# Altium schematic sheet CAN.SchDoc(CAN)
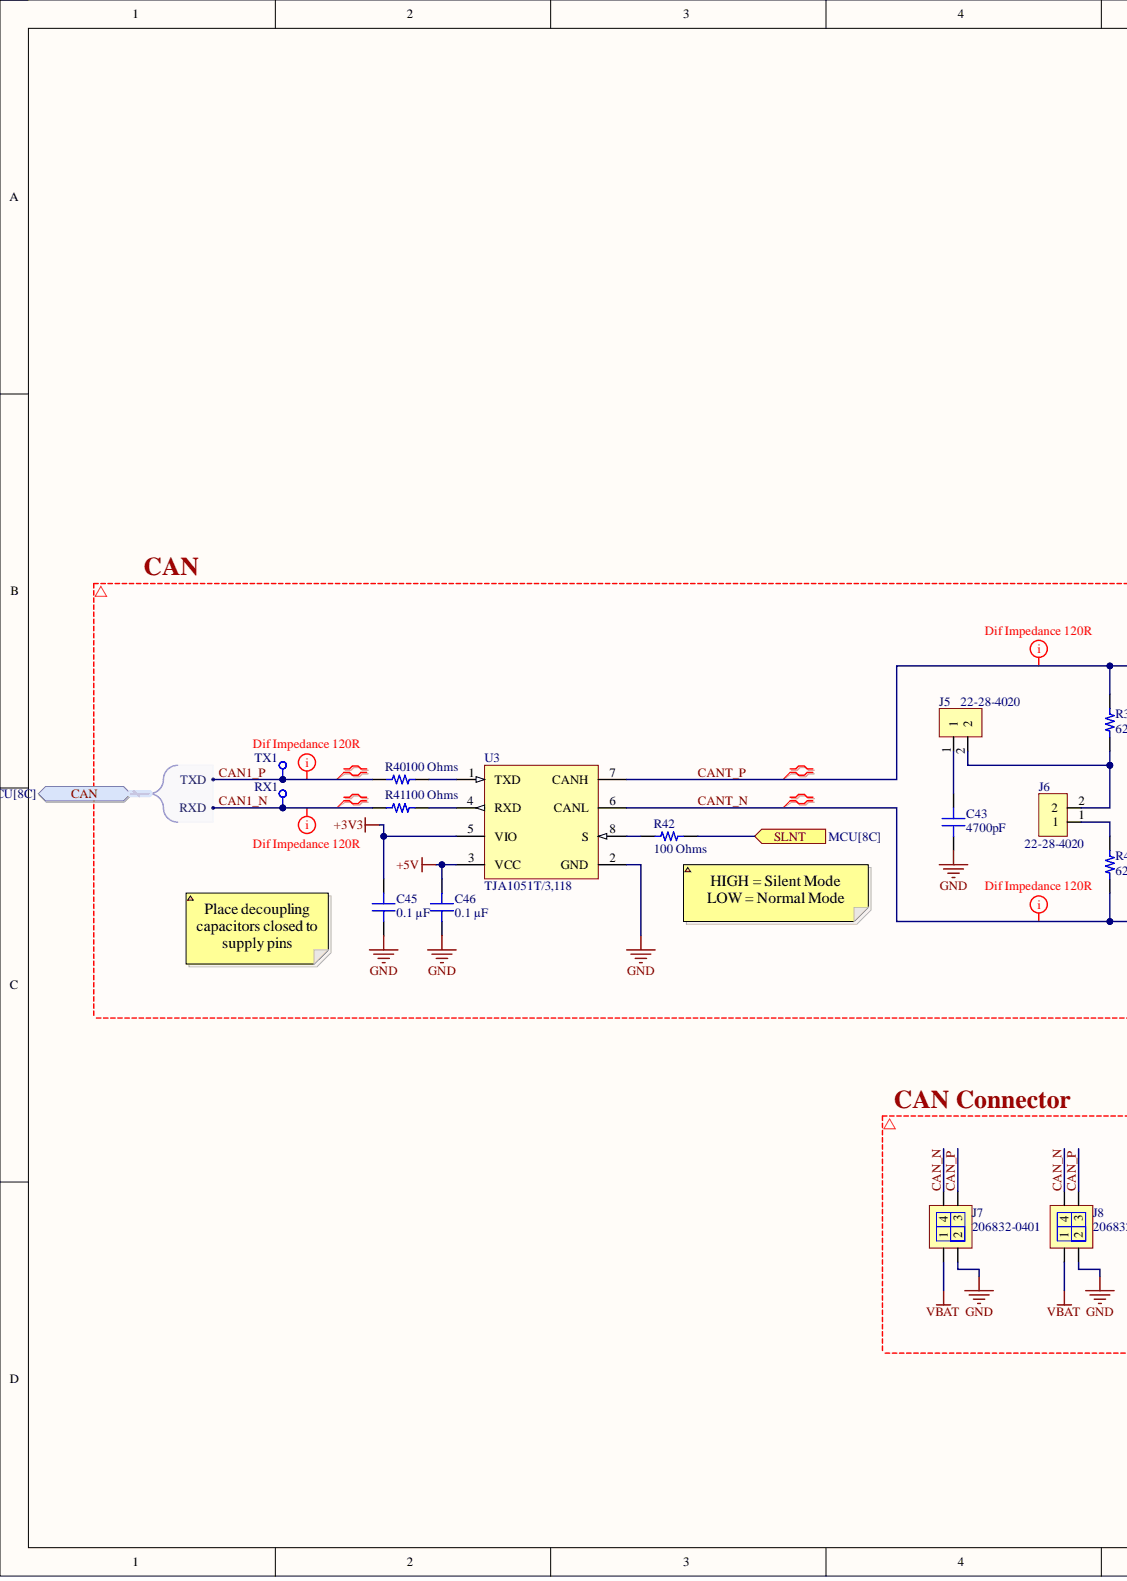
[diagram p0: CAN.SchDoc, left]
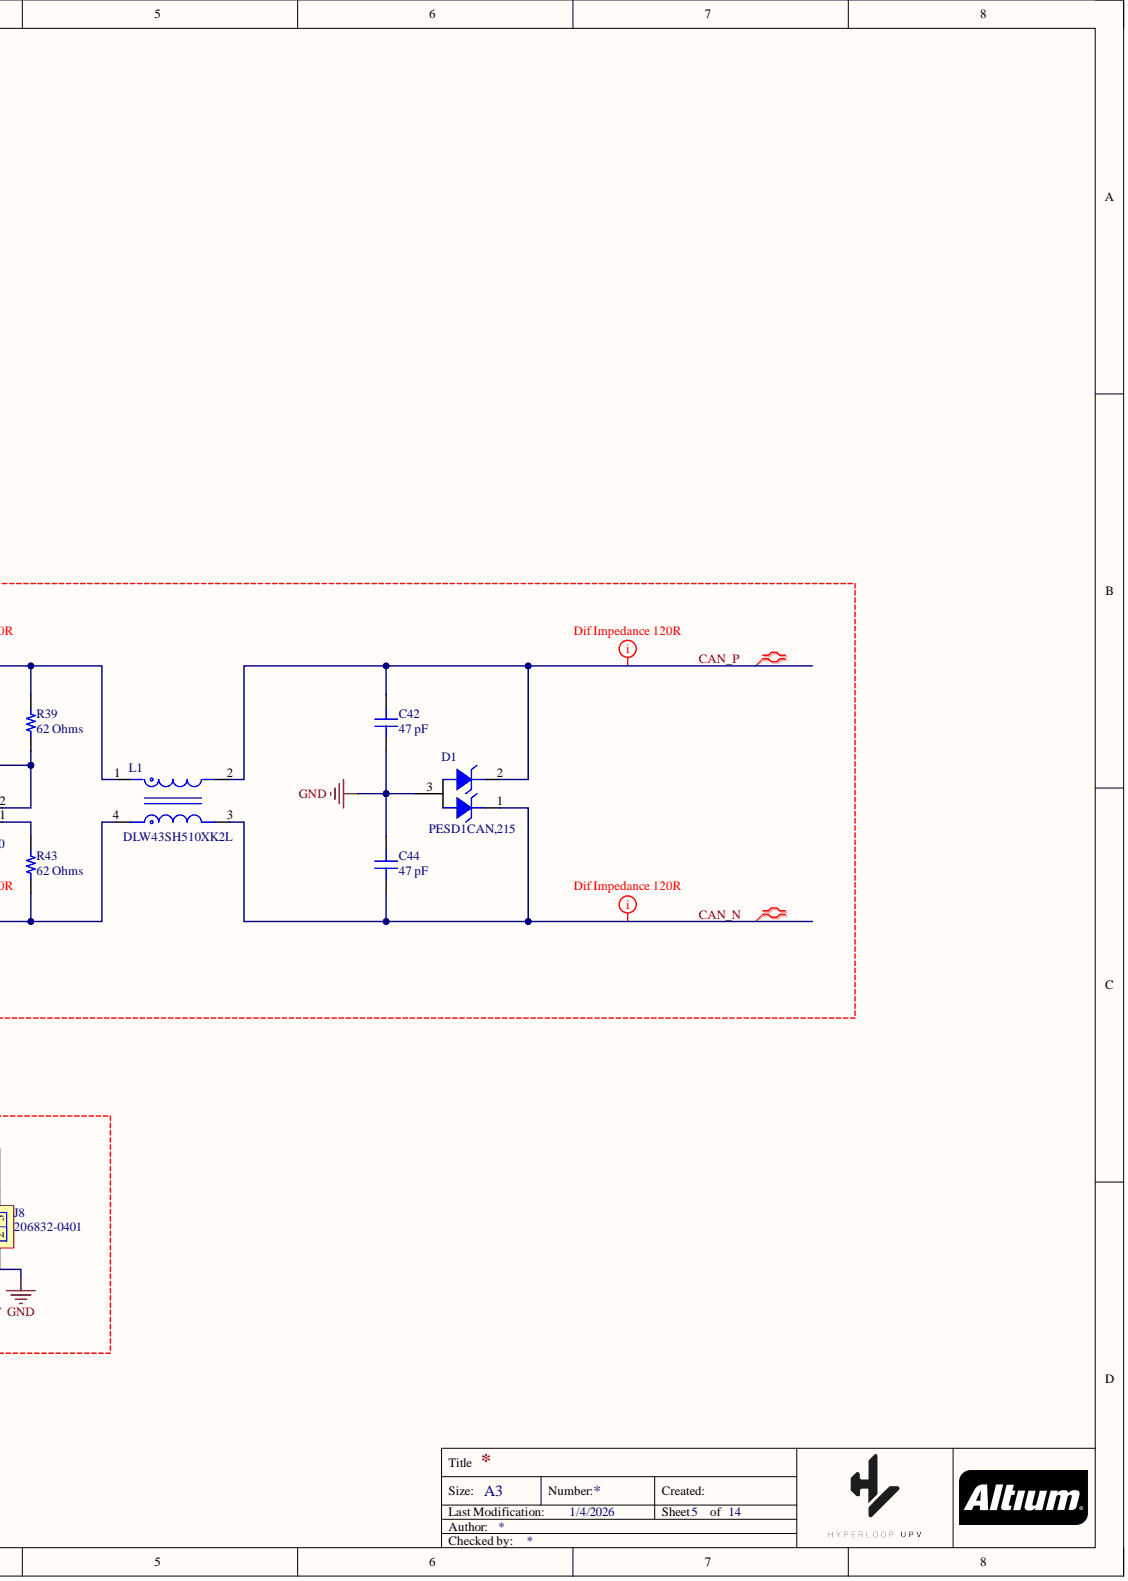
[diagram p1: CAN.SchDoc, right]

## Parts
C42  47 pF
C43  4700pF
C44  47 pF
C45  0.1 µF
C46  0.1 µF
D1  PESD1CAN,215
J5  22-28-4020
J6  22-28-4020
J7  206832-0401
J8  206832-0401
L1  DLW43SH510XK2L
R39  62 Ohms
R40  100 Ohms
R41  100 Ohms
R42  100 Ohms
R43  62 Ohms
RX1  Test point 1.2mm  (value not drawn)
TX1  Test point 1.2mm  (value not drawn)
U3  TJA1051T/3,118

## Ports
CAN, CAN.RXD, CAN.TXD, SLNT

## Nets
+3V3: C45.2 U3.5(VIO)
+5V: C46.2 U3.3(VCC)
GND: C42.1 C43.1 C44.2 C45.1 C46.1 D1.3 J7.2 J8.2 U3.2(GND)
VBAT: J7.1 J8.1
CAN1_N: R41.1 RX1.1 ; port CAN
CAN1_P: R40.1 TX1.1 ; port CAN
CAN_N: C44.1 D1.1 J7.4 J8.4 L1.3
CAN_P: C42.2 D1.2 J7.3 J8.3 L1.2
CANT_N: L1.4 R43.2 U3.6(CANL)
CANT_P: L1.1 R39.1 U3.7(CANH)
SLNT: R42.2 ; port SLNT
NetC43_2: C43.2 J5.1
NetJ5_2: J5.2 J6.2 R39.2
NetJ6_1: J6.1 R43.1
NetR40_2: R40.2 U3.1(TXD)
NetR41_2: R41.2 U3.4(RXD)
NetR42_1: R42.1 U3.8(S)

## Library data of the parts
C42 C44 = 885012006055: CAP CER 47PF 50V C0G/NP0 0603; manufacturer: Würth Elektronik; Database Table Name: Capacitors - Ceramic - 0603; Temperature Coefficient: C0G, NP0; Tolerance: ±5%; Applications: General Purpose; Capacitance: 47 pF; Component Kind: Standard; componentlink1description: Datasheet; componentlink1url: https://katalog.we-online.de/pbs/datasheet/885012006055.pdf; componentlink2description: DigiKey Link; componentlink2url: https://www.digikey.com/en/products/detail/würth-elektronik/885012006055/5453652; Device Package: 0603 (1608 Metric); lastupdated: 19/09/2022 13:01:16; Library Name: Celestial Altium Library - altium_library.DbLib; Lifecycle Status: Active; Minimum Order: 1; Mounting Type: Surface Mount, MLCC; Operating Temperature: -55°C ~ 125°C; Package / Case: 0603 (1608 Metric); packaging: TapeAndReel; Part Number: 885012006055; Part Status: Active; partid: 3510; price: 0.02; series: WCAP-CSGP; Size / Dimension: 0.063" L x 0.031" W (1.60mm x 0.80mm); Supplier 1: DigiKey; Supplier Part Number 1: 732-7797-1-ND; Thickness (Max): 0.034" (0.87mm); Value: 47 pF; Voltage - Rated: 50V
C43 = 06035C472JAT2A: CAP CER 4700PF 50V X7R 0603; manufacturer: AVX Corporation; Database Table Name: Capacitors - Ceramic - 0603; Tolerance: ±5%; Applications: General Purpose; Capacitance: 4700pF; Component Kind: Standard; componentlink1description: Datasheet; componentlink1url: http://datasheets.avx.com/X7RDielectric.pdf; componentlink2description: DigiKey Link; componentlink2url: http://digikey.com/product-detail/en/avx-corporation/06035C472JAT2A/478-10355-1-ND/6797516; Device Package: 0603 (1608 Metric); lastupdated: 02/09/2020 1:03:35; Library Name: Celestial Altium Library - altium_library.DbLib; Lifecycle Status: Active; Minimum Order: 1; Mounting Type: Surface Mount, MLCC; Operating Temperature: -55°C ~ 125°C; Package / Case: 0603 (1608 Metric); packaging: TapeAndReel; Part Number: 06035C472JAT2A; Part Status: Active; partid: 2778; price: 0.02; series: -; Size / Dimension: 0.063" L x 0.032" W (1.60mm x 0.81mm); Supplier 1: DigiKey; Supplier Part Number 1: 478-10355-1-ND; Temperature Coefficient: X7R; Thickness (Max): 0.035" (0.90mm); Value: 4700pF; Voltage - Rated: 50V
C45 C46 = C0603C104K5RAC7411: CAP CER 0.1UF 50V X7R 0603; manufacturer: KEMET; Database Table Name: Capacitors - Ceramic - 0603; Tolerance: ±10%; Applications: Bypass, Decoupling; Capacitance: 0.1 µF; Component Kind: Standard; componentlink1description: Datasheet; componentlink1url: https://api.kemet.com/component-edge/download/datasheet/C0603C104K5RAC7411.pdf; componentlink2description: DigiKey Link; componentlink2url: https://www.digikey.com/en/products/detail/kemet/C0603C104K5RAC7411/3317013; Device Package: 0603 (1608 Metric); lastupdated: 19/09/2022 13:01:20; Library Name: Celestial Altium Library - altium_library.DbLib; Lifecycle Status: Active; Minimum Order: 15000; Mounting Type: Surface Mount, MLCC; Operating Temperature: -55°C ~ 125°C; Package / Case: 0603 (1608 Metric); packaging: TapeAndReel; Part Number: C0603C104K5RAC7411; Part Status: Active; partid: 2233; price: 0.01; series: SMD Comm X7R; Size / Dimension: 0.063" L x 0.031" W (1.60mm x 0.80mm); Supplier 1: DigiKey; Supplier Part Number 1: 399-7374-2-ND; Temperature Coefficient: X7R; Thickness (Max): 0.035" (0.90mm); Value: 0.1 µF; Voltage - Rated: 50V
D1 = CMP-006-00233-1: Trans Voltage Suppressor Diode, 200W, 24V V(RWM), Bidirectional, 2 Element, Silicon, TO-236AB; Case/Package: SOT23; Component Kind: Standard; Max Operating Temperature: 150°C; Min Operating Temperature: -65°C; Mounting Technology: SMD; Pins: 3; RoHS Compliant: Yes; Library Name: Hyperloop UPV
J5 J6 = CMP-006-00230-2: Board Connector, 2 Contact(s), 1 Row(s), Male, Straight, 0.1 inch Pitch, Solder Terminal, Locking, Black Insulator, Receptacle; Maximum Current: 4 A; Maximum Voltage: 250 V; Pitch: 2.54 mm; Rows: 1; Case/Package: PCB Header; Component Kind: Standard; Connector Type: Straight; Gender: Male; Max Operating Temperature: 75°C; Min Operating Temperature: 0°C; Mounting Technology: THT; Pins: 2; RoHS Compliant: Yes; Library Name: Hyperloop UPV
J7 J8 = CMP-006-00301-4: MICRO-FIT Plus Header Assembly Dual Row 4 Position Straight Tin; Connector Type: Micro-Fit+; Gender: Male; Max Current: 12.5 A; Max Voltage: 600V AC (RMS)/DC; Component Kind: Standard; Max Operating Temperature: 105°C; Min Operating Temperature: -40°C; Mounting Technology: THT; Pins: 4; RoHS Compliant: Yes; Library Name: Hyperloop UPV
L1 = CMP-006-00232-4: Ferrite Chip, 2 Function(s), 50V, 0.23A, 4 Pin(s); Current Rating: 230mA; Inductance: 51uH; Component Kind: Standard; Height: 2.6mm; Length: 4.5mm; Max Operating Temperature: 125°C; Min Operating Temperature: -40°C; Mounting Technology: SMD; Pins: 4; RoHS Compliant: Yes; Voltage Rating: 50V; Width: 3.2mm; Library Name: Hyperloop UPV
R39 R43 = RNCS0603BKE10K0: RES 10K OHM 0.1% 1/16W 0603; Manufacturer: Stackpole Electronics Inc; Database Table Name: Resistors - Surface Mount - 0603; Power (Watts): 0.063W, 1/16W; Resistance: 10 kOhms; Temperature Coefficient: ±25ppm/°C; Component Kind: Standard; ComponentLink1Description: Datasheet; ComponentLink1URL: https://www.seielect.com/catalog/sei-rncs_rnch.pdf; ComponentLink2Description: DigiKey Link; ComponentLink2URL: https://www.digikey.com/en/products/detail/stackpole-electronics-inc/RNCS0603BKE10K0/1708836; Composition: Thin Film; Device Package: 0603 (1608 Metric); Features: Anti-Corrosive, Automotive AEC-Q200, Moisture Resistant; Height - Seated (Max): 0.022" (0.55mm); LastUpdated: 09-Feb-24 20:43:37; Library Name: Celestial Altium Library - altium_library.DbLib; Lifecycle Status: Active; Minimum Order: 1; Number of Terminations: 2; Operating Temperature: -55°C ~ 155°C; Package / Case: 0603 (1608 Metric); Packaging: TapeAndReel; Part Number: RNCS0603BKE10K0; Part Status: Active; PartId: 4838; Price: 0.06; Ratings: AEC-Q200; Series: RNCS; Size / Dimension: 0.061" L x 0.031" W (1.55mm x 0.80mm); Supplier 1: DigiKey; Supplier Device Package: 0603; Supplier Part Number 1: RNCS0603BKE10K0CT-ND; Tolerance: ±0.1%; Value: 62 Ohms
R40-R42 = CRCW0603100RFKEAC: RES 100 OHM 1% 1/10W 0603; Manufacturer: Vishay Dale; Database Table Name: Resistors - Surface Mount - 0603; Power (Watts): 0.1W, 1/10W; Temperature Coefficient: ±100ppm/°C; Component Kind: Standard; ComponentLink1Description: Datasheet; ComponentLink1URL: http://www.vishay.com/docs/28773/crcwce3.pdf; ComponentLink2Description: DigiKey Link; ComponentLink2URL: https://www.digikey.com/en/products/detail/vishay-dale/CRCW0603100RFKEAC/7928373; Composition: Thick Film; Device Package: 0603 (1608 Metric); Height - Seated (Max): 0.022" (0.55mm); LastUpdated: 19/09/2022 13:22:05; Library Name: Celestial Altium Library - altium_library.DbLib; Lifecycle Status: Active; Minimum Order: 1; Number of Terminations: 2; Operating Temperature: -55°C ~ 155°C; Package / Case: 0603 (1608 Metric); Packaging: CutTape; Part Number: CRCW0603100RFKEAC; Part Status: Active; PartId: 12546; Price: 0.01; Resistance: 100 Ohms; Series: CRCW-C; Supplier 1: DigiKey; Supplier Device Package: 0603; Supplier Part Number 1: 541-3951-1-ND; Tolerance: ±1%; Value: 100 Ohms
RX1 TX1 = CMP-006-00250-2: 1.2mm Test point; Component Kind: Standard; Library Name: Hyperloop UPV
U3 = CMP-IC-00001-001-4: High Speed CAN Transceiver; Manufacturer: NXP; Max Supply Voltage: 5.5V; Min Supply Voltage: 4.5V; Pitch: 1.27mm; Qualification: AEC-Q100; Case/Package: SOIC 8; Component Kind: Standard; Height: 1.75mm; Length: 6.20mm; Max Operating Temperature: 125°C; Min Operating Temperature: -40°C; Mounting Technology: SMD; Pins: 8; RoHS Compliant: Yes; Width: 5.00mm; Library Name: Hyperloop UPV
